# Altium schematic sheet main.SchDoc(main)
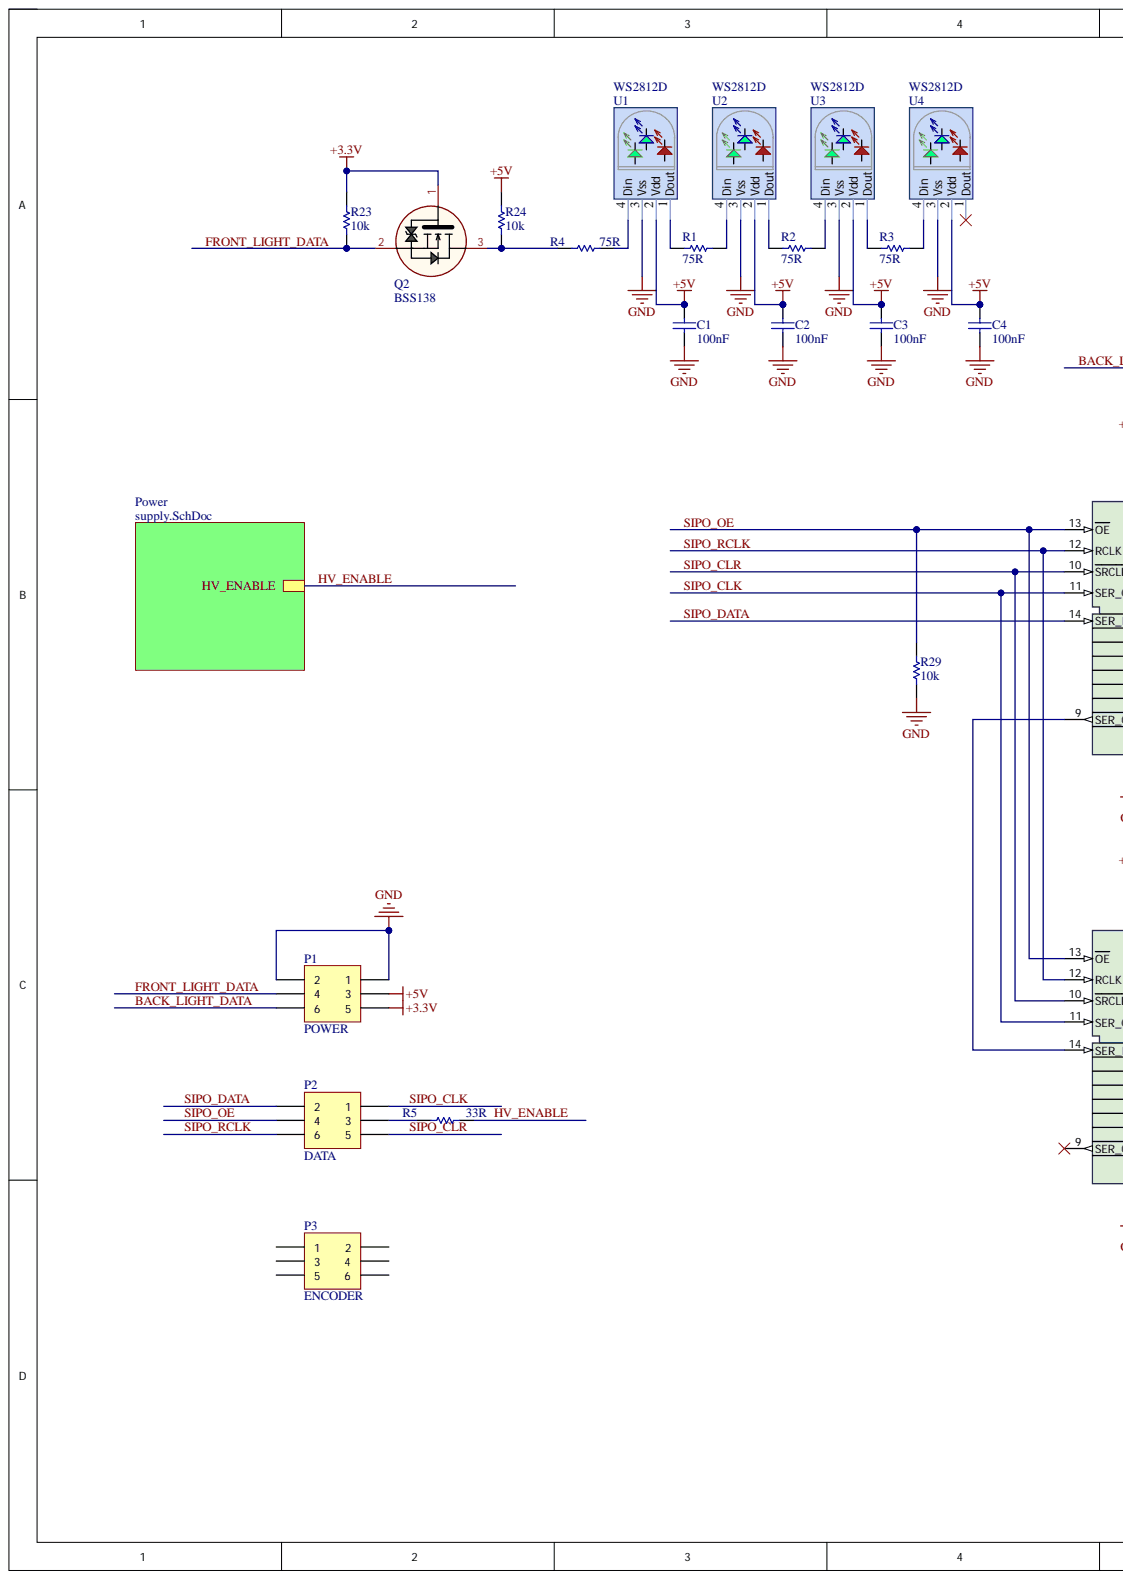
[diagram p0: main.SchDoc, left]
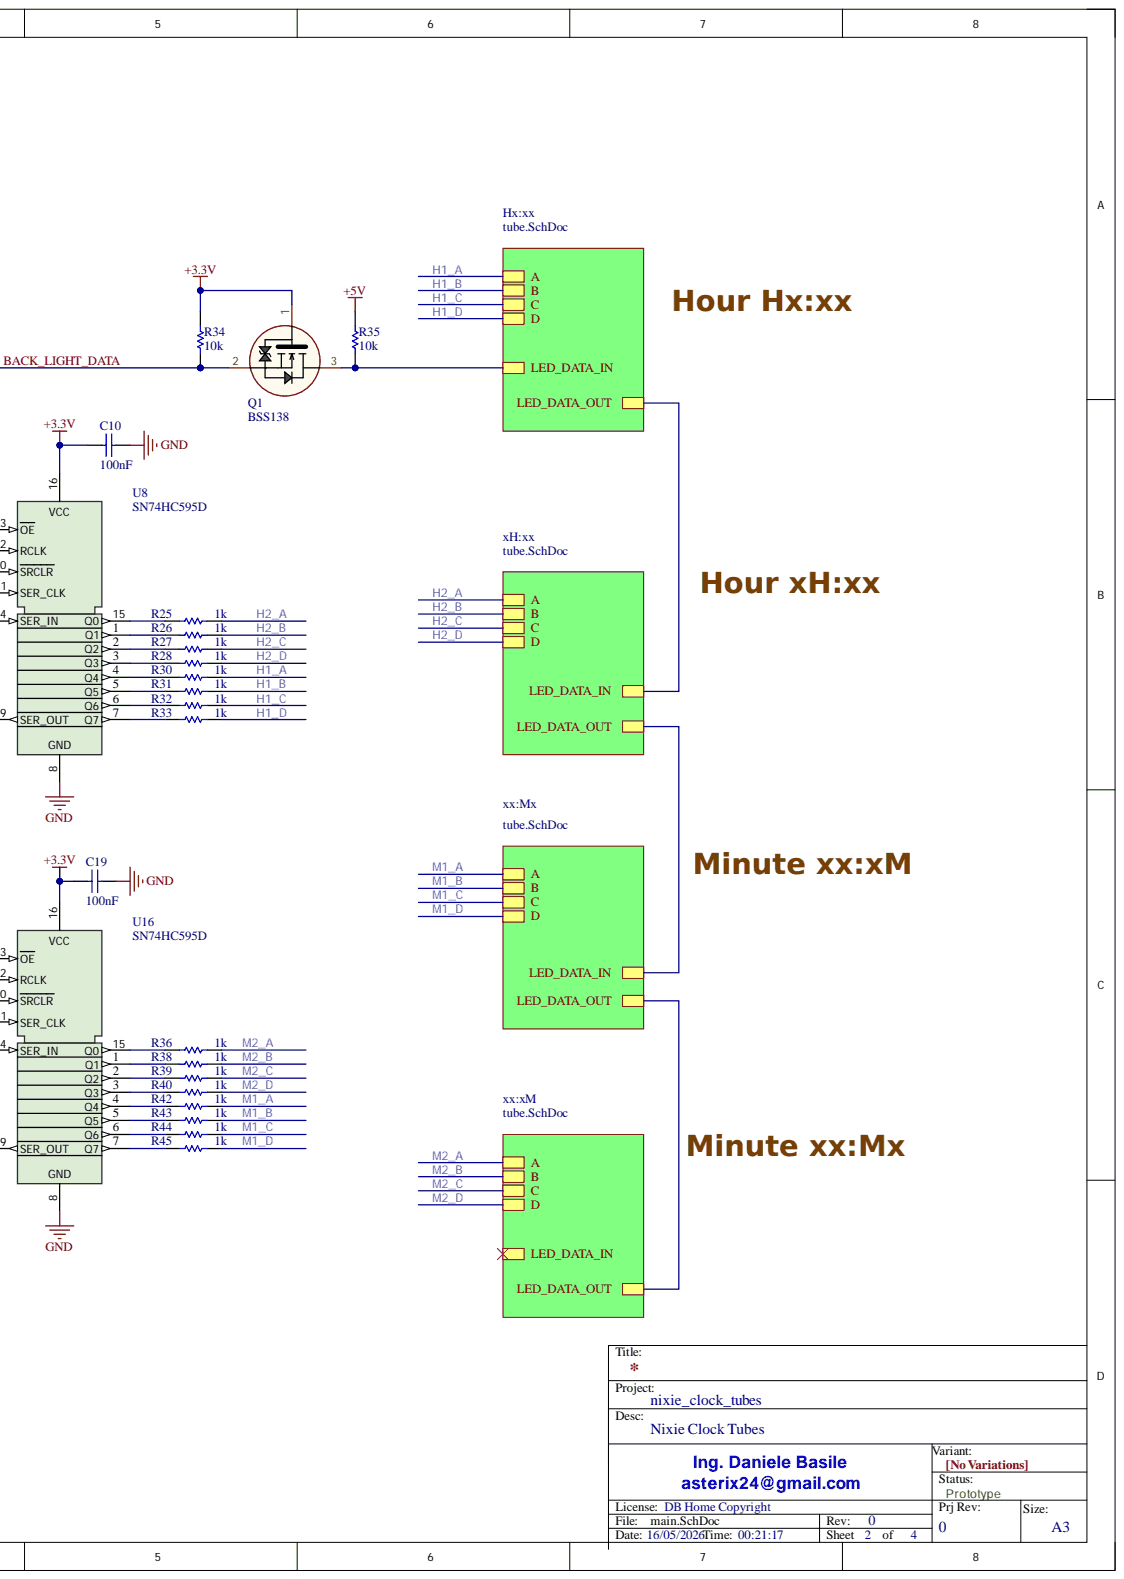
[diagram p1: main.SchDoc, right]

## Parts
C1  100nF  [0603_[1608]]
C2  100nF  [0603_[1608]]
C3  100nF  [0603_[1608]]
C4  100nF  [0603_[1608]]
C10  100nF  [0603_[1608]]
C19  100nF  [0603_[1608]]
P1  POWER  [HEADER_2X3_2.54MM_THD]
P2  DATA  [HEADER_2X3_2.54MM_THD]
P3  ENCODER  [HEADER_2X3_2.54MM_THD]
Q1  BSS138  [SOT-23]
Q2  BSS138  [SOT-23]
R1  75R  [0603_[1608]]
R2  75R  [0603_[1608]]
R3  75R  [0603_[1608]]
R4  75R  [0603_[1608]]
R5  33R  [0603_[1608]]
R23  10k  [0603_[1608]]
R24  10k  [0603_[1608]]
R25  1k  [0603_[1608]]
R26  1k  [0603_[1608]]
R27  1k  [0603_[1608]]
R28  1k  [0603_[1608]]
R29  10k  [0603_[1608]]
R30  1k  [0603_[1608]]
R31  1k  [0603_[1608]]
R32  1k  [0603_[1608]]
R33  1k  [0603_[1608]]
R34  10k  [0603_[1608]]
R35  10k  [0603_[1608]]
R36  1k  [0603_[1608]]
R38  1k  [0603_[1608]]
R39  1k  [0603_[1608]]
R40  1k  [0603_[1608]]
R42  1k  [0603_[1608]]
R43  1k  [0603_[1608]]
R44  1k  [0603_[1608]]
R45  1k  [0603_[1608]]
U1  WS2812D  [R5_RGB_LED_THD]
U2  WS2812D  [R5_RGB_LED_THD]
U3  WS2812D  [R5_RGB_LED_THD]
U4  WS2812D  [R5_RGB_LED_THD]
U8  SN74HC595D  [soic16]
U16  SN74HC595D  [soic16]

## Nets
+3.3V: C10.2 C19.2 P1.5 Q1.1 Q2.1 R23.1 R34.1 U8.16 U16.16
+5V: C1.1 C2.1 C3.1 C4.1 P1.3 R24.1 R35.1 U1.2(Vdd) U2.2(Vdd) U3.2(Vdd) U4.2(Vdd)
GND: C1.2 C2.2 C3.2 C4.2 C10.1 C19.1 P1.1 P1.2 R29.2 U1.3(Vss) U2.3(Vss) U3.3(Vss) U4.3(Vss) U8.8 U16.8
BACK_LIGHT_DATA: P1.6 Q1.2 R34.2
FRONT_LIGHT_DATA: P1.4 Q2.2 R23.2
H1_A: R30.1
H1_B: R31.1
H1_C: R32.1
H1_D: R33.1
H2_A: R25.1
H2_B: R26.1
H2_C: R27.1
H2_D: R28.1
HV_ENABLE: R5.1
M1_A: R42.1
M1_B: R43.1
M1_C: R44.1
M1_D: R45.1
M2_A: R36.1
M2_B: R38.1
M2_C: R39.1
M2_D: R40.1
SIPO_CLK: P2.1 U8.11(SER_CLK) U16.11(SER_CLK)
SIPO_CLR: P2.5 U8.10(SRCLR) U16.10(SRCLR)
SIPO_DATA: P2.2 U8.14(SER_IN)
SIPO_OE: P2.4 R29.1 U8.13(OE) U16.13(OE)
SIPO_RCLK: P2.6 U8.12(RCLK) U16.12(RCLK)
NetP2_3: P2.3 R5.2
NetP3_1: P3.1
NetP3_2: P3.2
NetP3_3: P3.3
NetP3_4: P3.4
NetP3_5: P3.5
NetP3_6: P3.6
NetQ1_3: Q1.3 R35.2
NetQ2_3: Q2.3 R4.2 R24.2
NetR1_1: R1.1 U1.1(Dout)
NetR1_2: R1.2 U2.4(Din)
NetR2_1: R2.1 U2.1(Dout)
NetR2_2: R2.2 U3.4(Din)
NetR3_1: R3.1 U3.1(Dout)
NetR3_2: R3.2 U4.4(Din)
NetR4_1: R4.1 U1.4(Din)
NetR25_2: R25.2 U8.15(Q0)
NetR26_2: R26.2 U8.1(Q1)
NetR27_2: R27.2 U8.2(Q2)
NetR28_2: R28.2 U8.3(Q3)
NetR30_2: R30.2 U8.4(Q4)
NetR31_2: R31.2 U8.5(Q5)
NetR32_2: R32.2 U8.6(Q6)
NetR33_2: R33.2 U8.7(Q7)
NetR36_2: R36.2 U16.15(Q0)
NetR38_2: R38.2 U16.1(Q1)
NetR39_2: R39.2 U16.2(Q2)
NetR40_2: R40.2 U16.3(Q3)
NetR42_2: R42.2 U16.4(Q4)
NetR43_2: R43.2 U16.5(Q5)
NetR44_2: R44.2 U16.6(Q6)
NetR45_2: R45.2 U16.7(Q7)
NetU4_1: U4.1(Dout)
NetU8_9: U8.9(SER_OUT) U16.14(SER_IN)
NetU16_9: U16.9(SER_OUT)
LED_DATA_IN:
LED_DATA_OUT:

## Library data of the parts
C1-C4 C10 C19 = Cap: Capacitor; Component Kind: Standard; ComponentLink1Description: New link; Signal Integrity: Cap; Simulation: CAP; Value: 100nF; Library Name: develer_generic.schlib
P1-P3 = Header 3X2: Header, 3-Pin, Dual row; Component Kind: Standard; LatestRevisionDate: 17-Jul-2002; LatestRevisionNote: Re-released for DXP Platform.; Library Name: Miscellaneous Connectors.IntLib; Signal Integrity: Connector; Publisher: Altium Limited
Q1 Q2 = BSS138: N-MOSFET 50V 0,22A; Component Kind: Standard; MountTechnology: SMT; Revision: 0.1; Library Name: develer_components.SchLib; Publisher: Develer srl
R1-R5 R23-R36 R38-R40 R42-R45 = Res: Resistor; Component Kind: Standard; MountTechnology: SMT; Revision: 0.1; Signal Integrity: Res; Simulation: RESISTOR; Library Name: develer_generic.schlib; Publisher: Develer srl
U1-U4 = WS2812D: Adressable Led RGB 1wire, THD; CODE LCSC: C190565; Component Kind: Standard; MountTechnology: SMT; Revision: 0.1; Library Name: develer_components.SchLib; Publisher: Develer srl
U8 U16 = SN74HC595D: 8-Bit Shift Registers With 3-State Output Registers; Component Kind: Standard; MountTechnology: SMT; Revision: 0.1; Supplier 1: Digi-Key; Supplier 2: Newark; Supplier 3: Newark; Supplier 4: Avnet; Supplier Part Number 1: 497-2344-5-ND; Supplier Part Number 2: 26AC5117; Supplier Part Number 3: 89K1130; Supplier Part Number 4: ULN2003A; Library Name: develer_components.SchLib; Publisher: Develer srl
